# Altium schematic sheet Schematic Prints("All Documents",Physical)
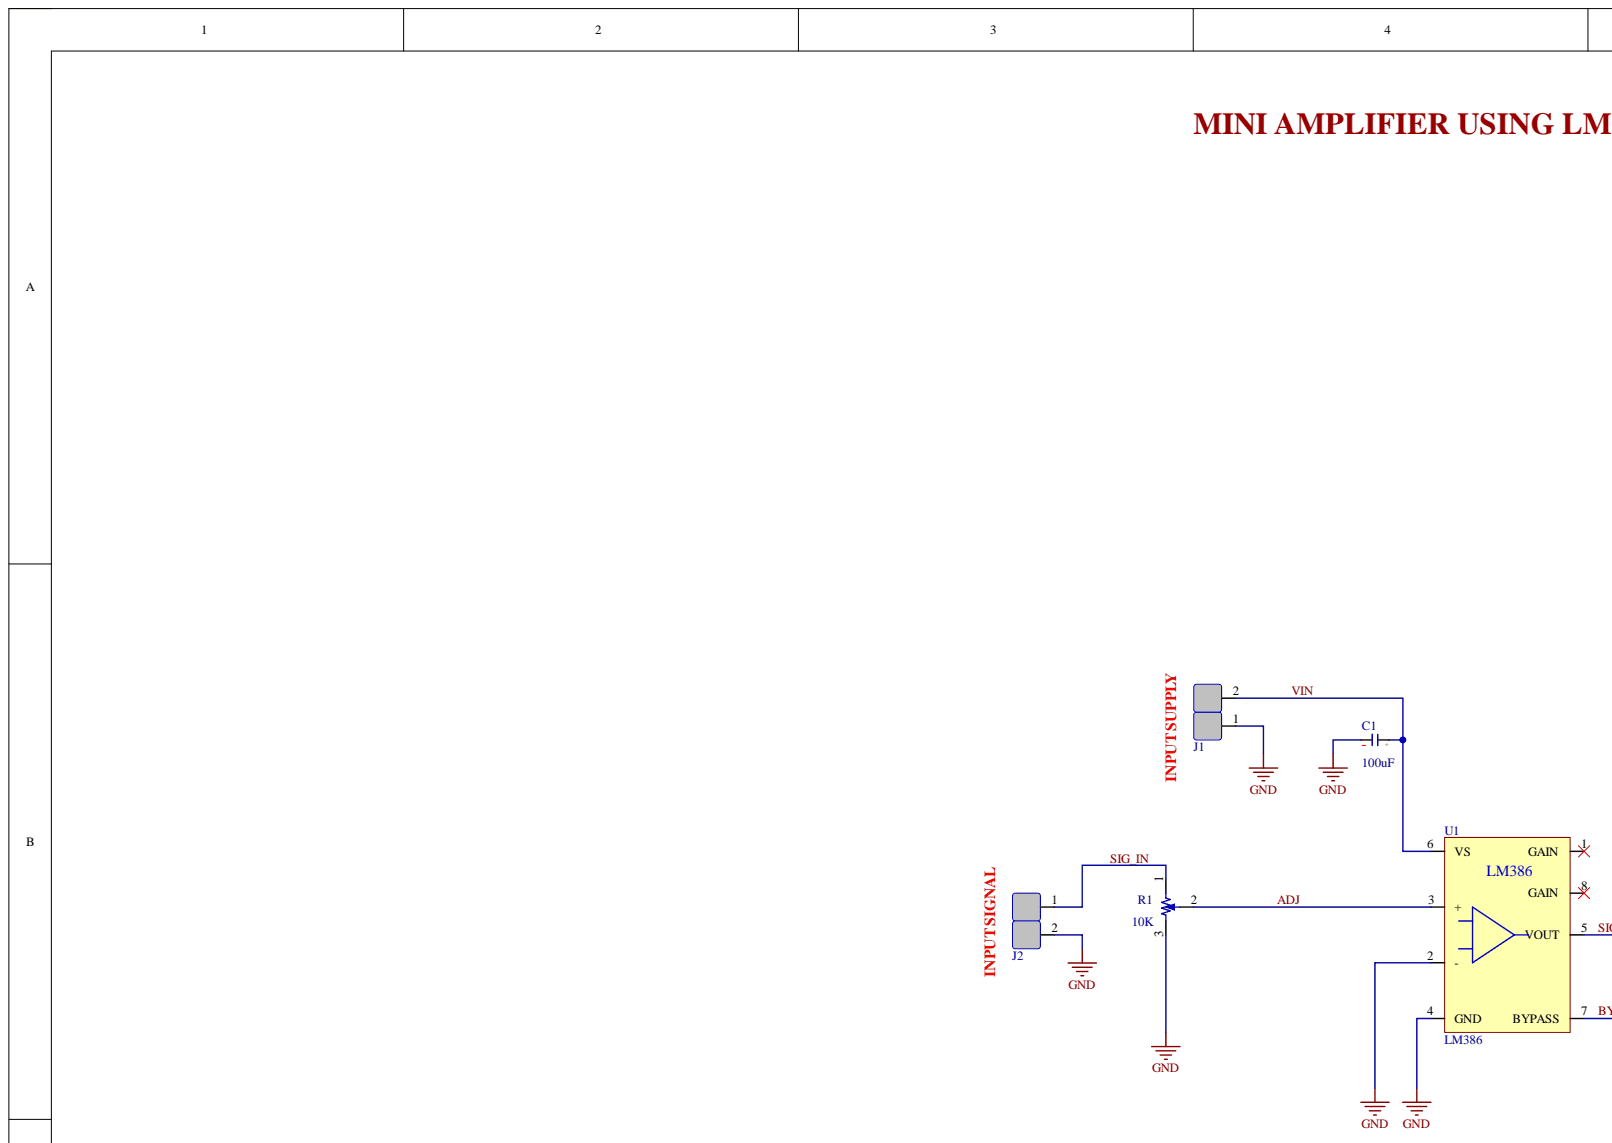
[diagram p0: Schematic Prints("All Documents",Physical), top left]
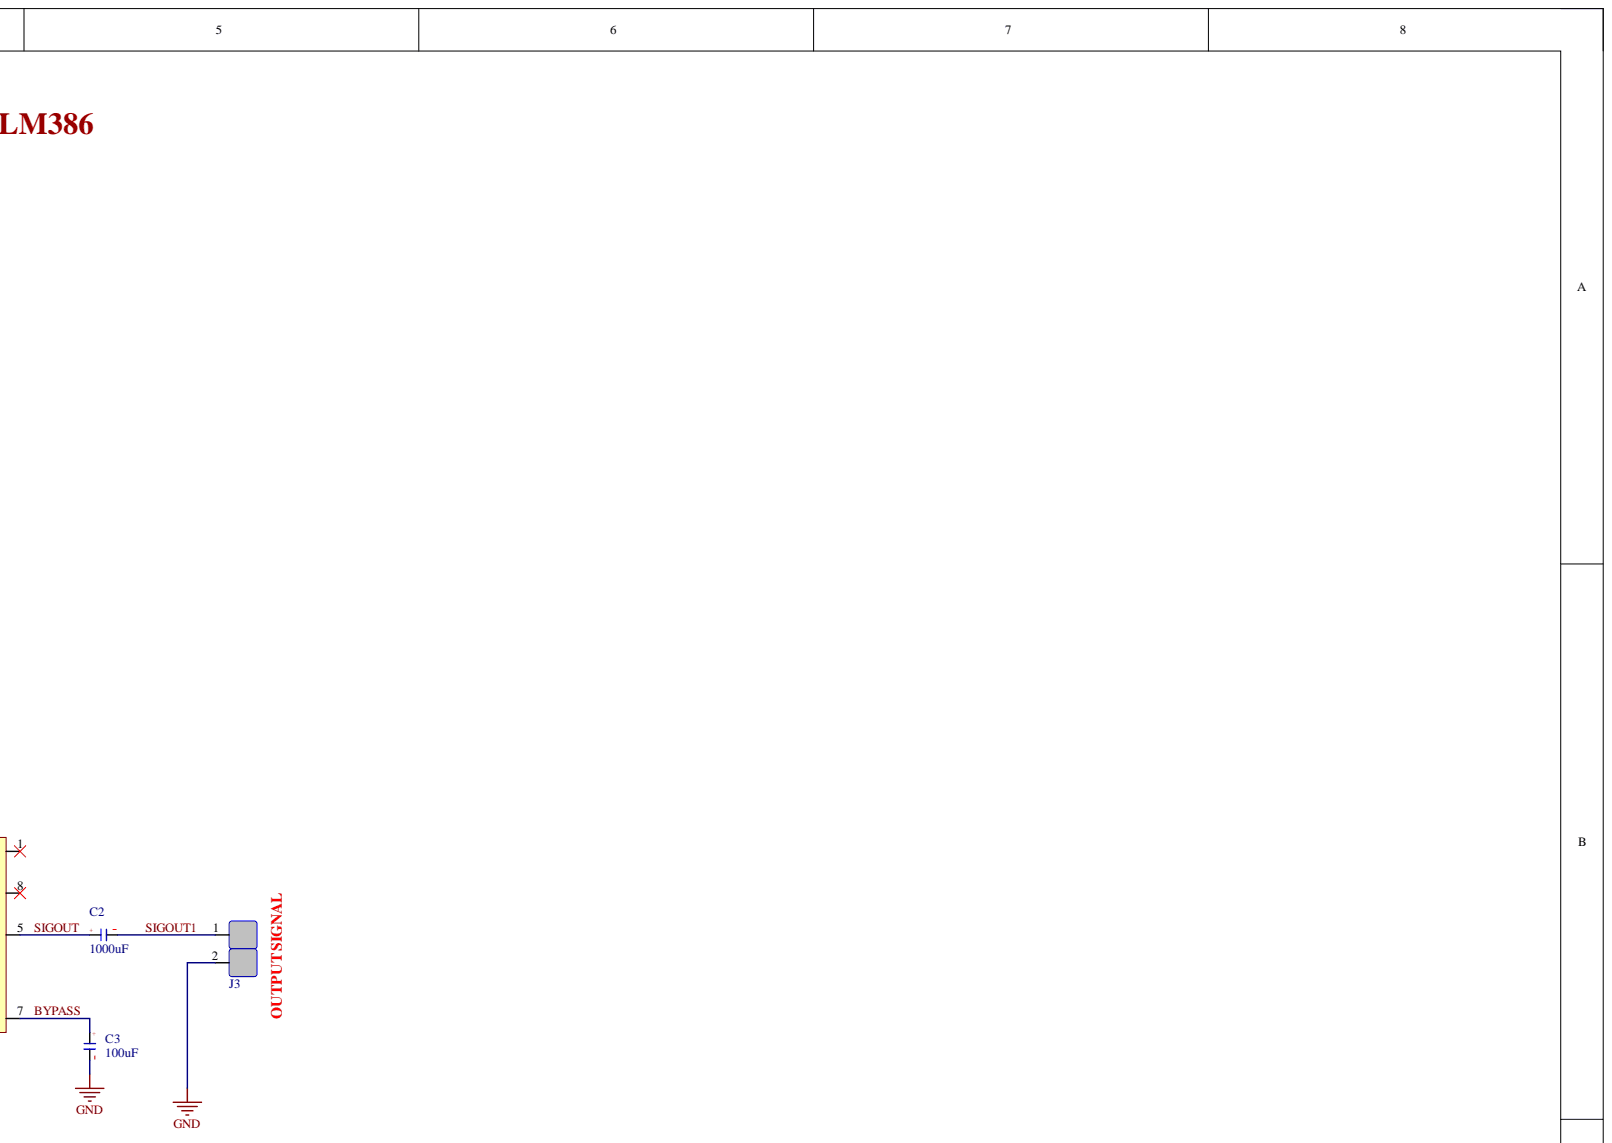
[diagram p1: Schematic Prints("All Documents",Physical), top right]
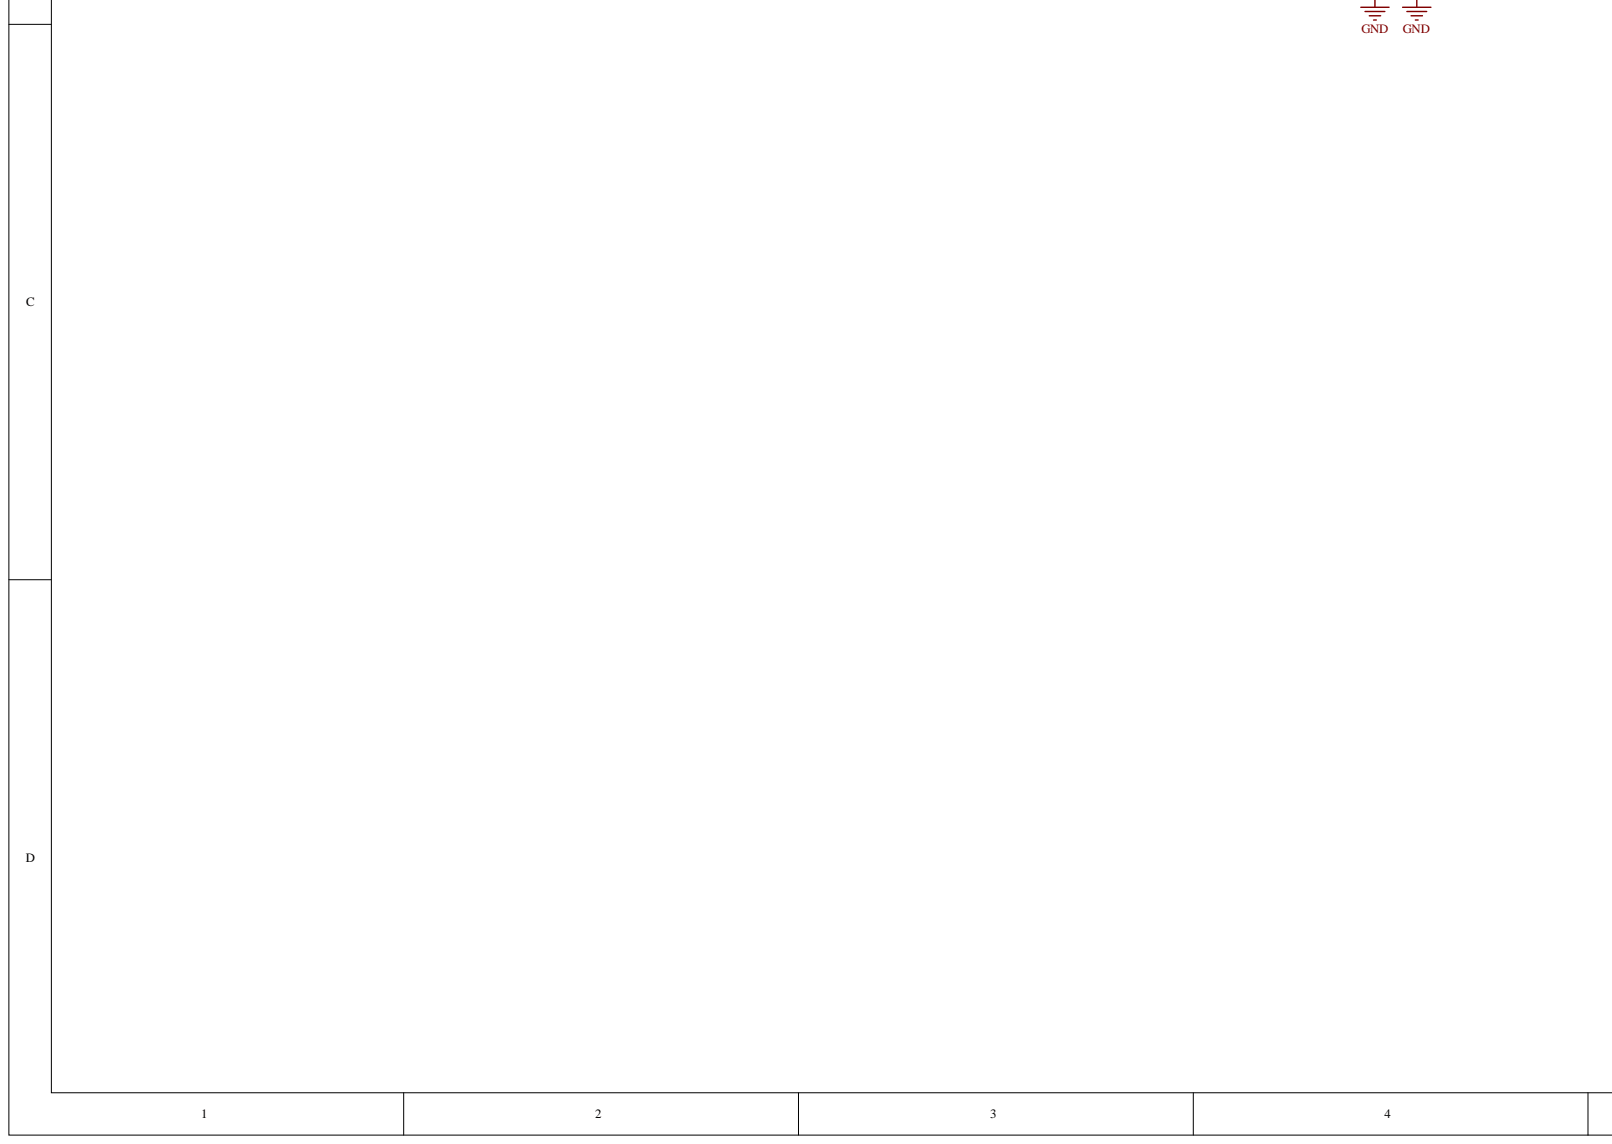
[diagram p2: Schematic Prints("All Documents",Physical), bottom left]
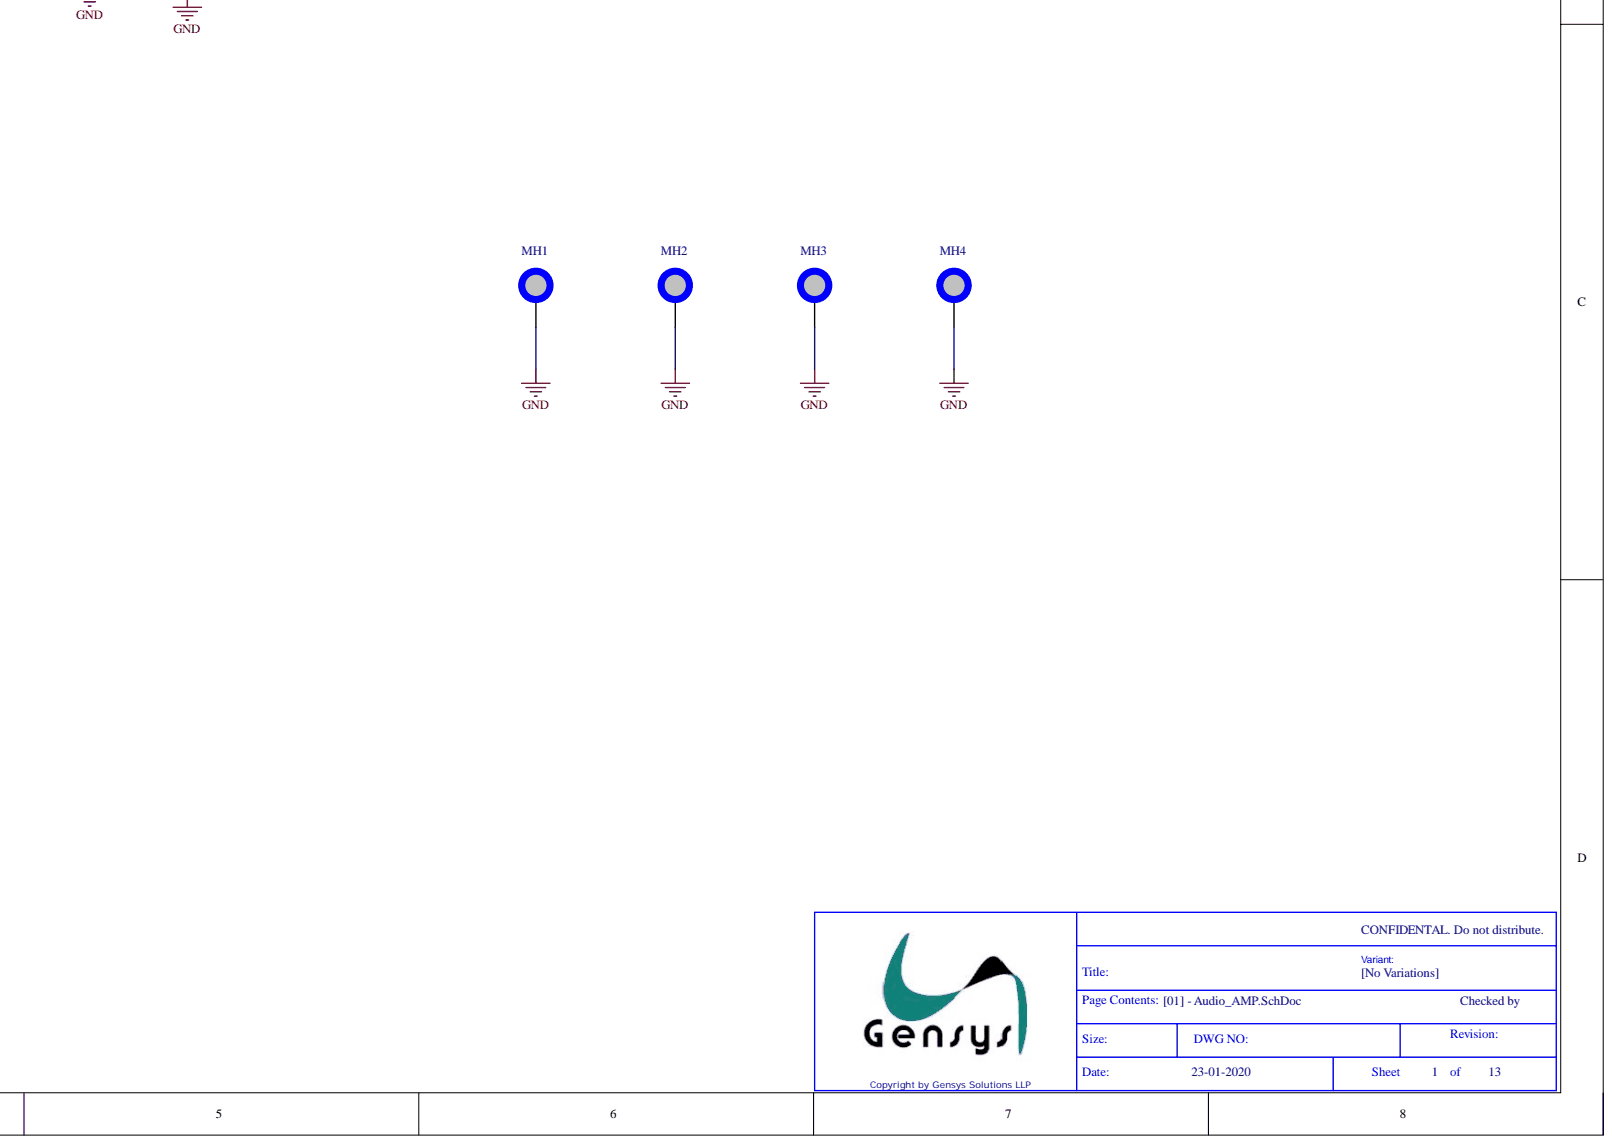
[diagram p3: Schematic Prints("All Documents",Physical), bottom right]

## Parts
C1  100uF  [100uF_Electrolytic]
C2  1000uF  [CAP_1000uF_25V]
C3  100uF  [100uF_Electrolytic]
J1  CON2  [CON2]  (value not drawn)
J2  CON2  [CON2]  (value not drawn)
J3  CON2  [CON2]  (value not drawn)
MH1  MH_6MMC_3.5MMD  [MH_6MMD_3.5MMD]  (value not drawn)  (no BOM)
MH2  MH_6MMC_3.5MMD  [MH_6MMD_3.5MMD]  (value not drawn)  (no BOM)
MH3  MH_6MMC_3.5MMD  [MH_6MMD_3.5MMD]  (value not drawn)  (no BOM)
MH4  MH_6MMC_3.5MMD  [MH_6MMD_3.5MMD]  (value not drawn)  (no BOM)
R1  10K  [10k_pot]
U1  LM386  [SOIC8]

## Nets
GND: C1.2 C3.2 J1.1 J2.2 J3.2 MH1.1 MH2.1 MH3.1 MH4.1 R1.3 U1.2(-) U1.4(GND)
ADJ: R1.2 U1.3(+)
BYPASS: C3.1 U1.7(BYPASS)
SIG_IN: J2.1 R1.1
SIGOUT: C2.1 U1.5(VOUT)
SIGOUT1: C2.2 J3.1
VIN: C1.1 J1.2 U1.6(VS)
NetU1_1: U1.1(GAIN)
NetU1_8: U1.8(GAIN)

## Library data of the parts
C1 C3 = C_100uF_25V: CAP ALUM 100UF 20% 25V SMD; Manufacturer: Panasonic; Manufacturer Part Number: EEE-1EA101XP; Leakage Current: 3uA; Life (Hours): 2000Hour; Polarity: Polar; Ripple Current: 143mA; Capacitance: 100uF; Case/Package: Radial; Category: Aluminum Electrolytic Capacitors; Component Kind: Standard; ComponentLink1Description: https://datasheet.ciiva.com/16201/aba0000ce2-16201065.pdf?src-supplier=Future+Electronics; ComponentLink1URL: https://datasheet.ciiva.com/16201/aba0000ce2-16201065.pdf?src-supplier=Future+Electronics; ComponentLink2Description: https://datasheet.ciiva.com/24022/aba0000ce2-40801-24022875.pdf?src-supplier=Mouser; ComponentLink2URL: https://datasheet.ciiva.com/24022/aba0000ce2-40801-24022875.pdf?src-supplier=Mouser; ComponentLink3Description: https://datasheet.ciiva.com/30108/11aba0000ce2-30108047.pdf?src-supplier=Onlinecomponents.com; ComponentLink3URL: https://datasheet.ciiva.com/30108/11aba0000ce2-30108047.pdf?src-supplier=Onlinecomponents.com; ComponentLink4Description: https://datasheet.ciiva.com/17529/aba0000ce2-17529557.pdf?src-supplier=Avnet+Europe; ComponentLink4URL: https://datasheet.ciiva.com/17529/aba0000ce2-17529557.pdf?src-supplier=Avnet+Europe; Contact Plating: Tin; Diameter: 6.3mm; Height: 7.7mm; Height - Seated (Max): 0.303inch; Lead Free: Lead Free; Length: 8mm; Max Operating Temperature: 85°C; Min Operating Temperature: -40°C; Mount: Surface Mount,PCB; Package Quantity: 900; Packaging: Tape and Reel; Pricing 1: 1=0.47000, 10=0.32800, 100=0.19670 (USD); Radiation Hardening: No; REACH SVHC: Unknown; Ripple Current (AC): 143mA; RoHS: Yes; Stock 1: 198705; Supplier 1: Digi-Key; Supplier Part Number 1: PCE3898CT-ND; Termination: SMD/SMT; Tolerance: 20%; Voltage: 25V; Voltage Rating: 25V; Voltage Rating (DC): 25V; Library Name: MYPROJECT.SchLib
C2 = C_1000uF_25V: PANASONIC - EEV-FK1E102Q - ALUMINUM ELECTROLYTIC CAPACITOR, 1000UF, 25V, 20%, SMD, FULL REEL; Capacitance: 1mF; Dissipation Factor: 0.14%; ESR (Equivalent Series Resistance): 60mR; Leakage Current: 3uA; Life (Hours): 5000Hour; Material: Aluminium; Ripple Current: 1.1A; Case/Package: Radial; Category: Aluminum Electrolytic Capacitors; Component Kind: Standard; ComponentLink1Description: https://datasheet.ciiva.com/34303/1935609-34303379.pdf?src-supplier=Jameco; ComponentLink1URL: https://datasheet.ciiva.com/34303/1935609-34303379.pdf?src-supplier=Jameco; ComponentLink2Description: https://datasheet.ciiva.com/29313/443473-da-01-en-smd-elko-vfk-h13-1000-uf-25v-29313574.pdf?src-supplier=Conrad; ComponentLink2URL: https://datasheet.ciiva.com/29313/443473-da-01-en-smd-elko-vfk-h13-1000-uf-25v-29313574.pdf?src-supplier=Conrad; ComponentLink3Description: https://datasheet.ciiva.com/2984/aba0000ce120-2984080.pdf?src-supplier=Avnet; ComponentLink3URL: https://datasheet.ciiva.com/2984/aba0000ce120-2984080.pdf?src-supplier=Avnet; ComponentLink4Description: https://datasheet.ciiva.com/2984/aba0000ce120-2984081.pdf?src-supplier=Avnet; ComponentLink4URL: https://datasheet.ciiva.com/2984/aba0000ce120-2984081.pdf?src-supplier=Avnet; ComponentLink5Description: https://datasheet.ciiva.com/16200/aba0000ce120-16200647.pdf?src-supplier=Future+Electronics; ComponentLink5URL: https://datasheet.ciiva.com/16200/aba0000ce120-16200647.pdf?src-supplier=Future+Electronics; ComponentLink6Description: https://datasheet.ciiva.com/17355/aba0000ce120-17355688.pdf?src-supplier=Avnet+Europe; ComponentLink6URL: https://datasheet.ciiva.com/17355/aba0000ce120-17355688.pdf?src-supplier=Avnet+Europe; Contact Plating: Tin; Diameter: 12.5mm; Height: 13.5mm; Height - Seated (Max): 0.531inch; Impedance: 60mR; Lead Free: Lead Free; Length: 13.5mm; Manufacturer: Panasonic; Manufacturer Part Number: EEV-FK1E102Q; Max Operating Temperature: 105°C; Min Operating Temperature: -55°C; Mount: Surface Mount,PCB; Package Quantity: 1; Packaging: Tape and Reel; Pricing 1: 1=1.32000, 10=1.03900, 100=0.77890 (USD); Radiation Hardening: No; REACH SVHC: Unknown; Ripple Current (AC): 1.1A; RoHS: Yes; Stock 1: 88487; Supplier 1: Digi-Key; Supplier Part Number 1: PCE3462CT-ND; Termination: SMD/SMT; Tolerance: 20%; Voltage: 25V; Voltage Rating: 25V; Voltage Rating (DC): 25V; Width: 900um; Library Name: MYPROJECT.SchLib
J1-J3 = CON_2: Conn Terminal Blocks 2 POS 5mm Solder ST Thru-Hole 16A; Manufacturer: Phoenix Contact; Manufacturer Part Number: 1935161; Approvals: UL; Connector Type: Screw; Current: 18A; Current Rating: 17.5A; Max Supply Voltage: 250V; Number of Circuits: 2; Number of Levels: 1; Voltage: 300V; Voltage Rating (DC): 320V; Wire Gauge (Max): 26AWG; Wire Gauge (Min): 12AWG; Category: Terminal Blocks; Color: Green; Component Kind: Standard; ComponentLink1Description: https://datasheet.ciiva.com/15169/getdatasheetpartid-177903-15169663.pdf?src-supplier=Verical; ComponentLink1URL: https://datasheet.ciiva.com/15169/getdatasheetpartid-177903-15169663.pdf?src-supplier=Verical; ComponentLink2Description: https://datasheet.ciiva.com/16047/1935161-16047247.pdf?src-supplier=Onlinecomponents.com; ComponentLink2URL: https://datasheet.ciiva.com/16047/1935161-16047247.pdf?src-supplier=Onlinecomponents.com; ComponentLink3Description: https://datasheet.ciiva.com/824/combiconcompact5-0mmseries-824222.pdf?src-supplier=Digi-Key; ComponentLink3URL: https://datasheet.ciiva.com/824/combiconcompact5-0mmseries-824222.pdf?src-supplier=Digi-Key; Contact Material: Copper,Tin; Depth: 9mm; Flammability Rating: UL94 V-0; Height: 11.3mm; Housing Color: Green; Housing Material: Polyamide; Insulation Material: Nylon; Lead Free: Lead Free; Length: 9mm; Material: Nylon; Max Current Rating: 16A; Mount: Through Hole; Number of Contacts: 2; Number of Pins: 2; Number of Poles: 2; Number of Positions: 2; Number of Positions Per Level: 2; Number of Rows: 1; Orientation: Straight; Package Quantity: 250; Packaging: Bulk; Pitch: 5mm; Pricing 1: 1=0.43000, 10=0.41100, 50=0.39280, 100=0.38200, 250=0.35700, 500=0.32844, 1000=0.30350 (USD); Radiation Hardening: No; REACH SVHC: Unknown; RoHS: Yes; Stock 1: 35354; Supplier 1: Digi-Key; Supplier Part Number 1: 277-1667-ND; Termination: Solder; Voltage Rating: 250V; Weight: 0.067021oz; Width: 14.9mm; Wire/Cable Gauge: 26AWG; Library Name: MYPROJECT.SchLib
MH1-MH4 = MH_6MMC_3.5MMD: Mounting Hole 6mm Diameter, 3.5mm Drill; .Creator: MM; Library Name: MYPROJECT.SchLib
R1 = R_10K_pot: POT 10K OHM 1/8W CARBON LINEAR; Manufacturer: Bourns; Manufacturer Part Number: PDB182-K220K-103B; Actuator Diameter: 0.236inch; Actuator Length: 0.787inch; Max Power Dissipation: 125mW; Number of Gangs: 2; Number of Turns: 1; Resistance: 10kR; Tolerance: 20%; Category: Variable Resistors and Potentiometers; Component Kind: Standard; ComponentLink1Description: https://datasheet.ciiva.com/8701/pdb18-8701894.pdf?src-supplier=Avnet; ComponentLink1URL: https://datasheet.ciiva.com/8701/pdb18-8701894.pdf?src-supplier=Avnet; ComponentLink2Description: https://datasheet.ciiva.com/2154/pdb18-2154637.pdf?src-supplier=Digi-Key; ComponentLink2URL: https://datasheet.ciiva.com/2154/pdb18-2154637.pdf?src-supplier=Digi-Key; Composition: Carbon; Depth: 17mm; Length: 31.5mm; Max Operating Temperature: 50°C; Military Standard: Not; Min Operating Temperature: -10°C; Mount: Panel,Through Hole; Package Quantity: 50; Packaging: Bulk; Power Rating: 125mW; Pricing 1: 1=2.08000, 10=1.82700, 25=1.63800, 50=1.57500, 100=1.51200, 250=1.44900, 500=1.19700, 1000=1.13400, 5000=0.94500 (USD); Radiation Hardening: No; RoHS: Yes; Stock 1: 230; Supplier 1: Digi-Key; Supplier Part Number 1: PDB182-K220K-103B-ND; Taper: Linear; Termination: Pin; Type: Potentiometer; Library Name: MYPROJECT.SchLib
U1 = LM386: TEXAS INSTRUMENTS   LM386MX-1/NOPB   AUDIO POWER AMPLIFIER, CLASS AB 325mW SOIC-8; Manufacturer: TI National Semiconductor; Bandwidth: 300kHz; Gain: 20dB; Input Bias Current: 250nA; Load Impedance: 8R; Max Power Dissipation: 730mW; Max Supply Voltage: 12V; Min Supply Voltage: 4V; Nominal Supply Current: 4mA; Number of Channels: 1; Operating Supply Voltage: 9V; Power Supply Rejection Ratio (PSRR): 50dB; Supply Type: Single; Voltage Gain: 46dB; Case/Package: D; Category: Amplifiers - Op Amps, Buffer, Instrumentation; Component Kind: Standard; ComponentLink1Description: https://datasheet.ciiva.com/27051/1282302-27051816.pdf?src-supplier=Jameco; ComponentLink1URL: https://datasheet.ciiva.com/27051/1282302-27051816.pdf?src-supplier=Jameco; ComponentLink2Description: https://datasheet.ciiva.com/15132/getdatasheetpartid-145250-15132457.pdf?src-supplier=Verical; ComponentLink2URL: https://datasheet.ciiva.com/15132/getdatasheetpartid-145250-15132457.pdf?src-supplier=Verical; ComponentLink3Description: https://datasheet.ciiva.com/1482/1640767-1482306.pdf?src-supplier=Element14; ComponentLink3URL: https://datasheet.ciiva.com/1482/1640767-1482306.pdf?src-supplier=Element14; ComponentLink4Description: https://datasheet.ciiva.com/6625/lm386-6625407.pdf?src-supplier=Digi-Key; ComponentLink4URL: https://datasheet.ciiva.com/6625/lm386-6625407.pdf?src-supplier=Digi-Key; Contact Plating: Tin,Matte; Lead Free: Lead Free; Manufacturer Part Number: LM386MX-1/NOPB; Max Operating Temperature: 70°C; Max Output Power: 325mW; Min Operating Temperature: 0°C; Number of Pins: 8; Output Power: 325mW; Package Quantity: 2500; Packaging: Tape and Reel; Power Dissipation: 730mW; Pricing 1: 1=1.05000, 10=0.93600, 25=0.88840, 100=0.68210, 250=0.60276, 500=0.57104, 1000=0.44414 (USD); Radiation Hardening: No; REACH SVHC: No SVHC; RoHS: Yes; Stock 1: 4467; Supplier 1: Digi-Key; Supplier Part Number 1: LM386MX-1/NOPBCT-ND; Value: LM386; Library Name: MYPROJECT.SchLib
